# Altium schematic sheet Isolated Power Supplies Rev 1b.SchDoc("Isolated Power Supplies Rev 1b")
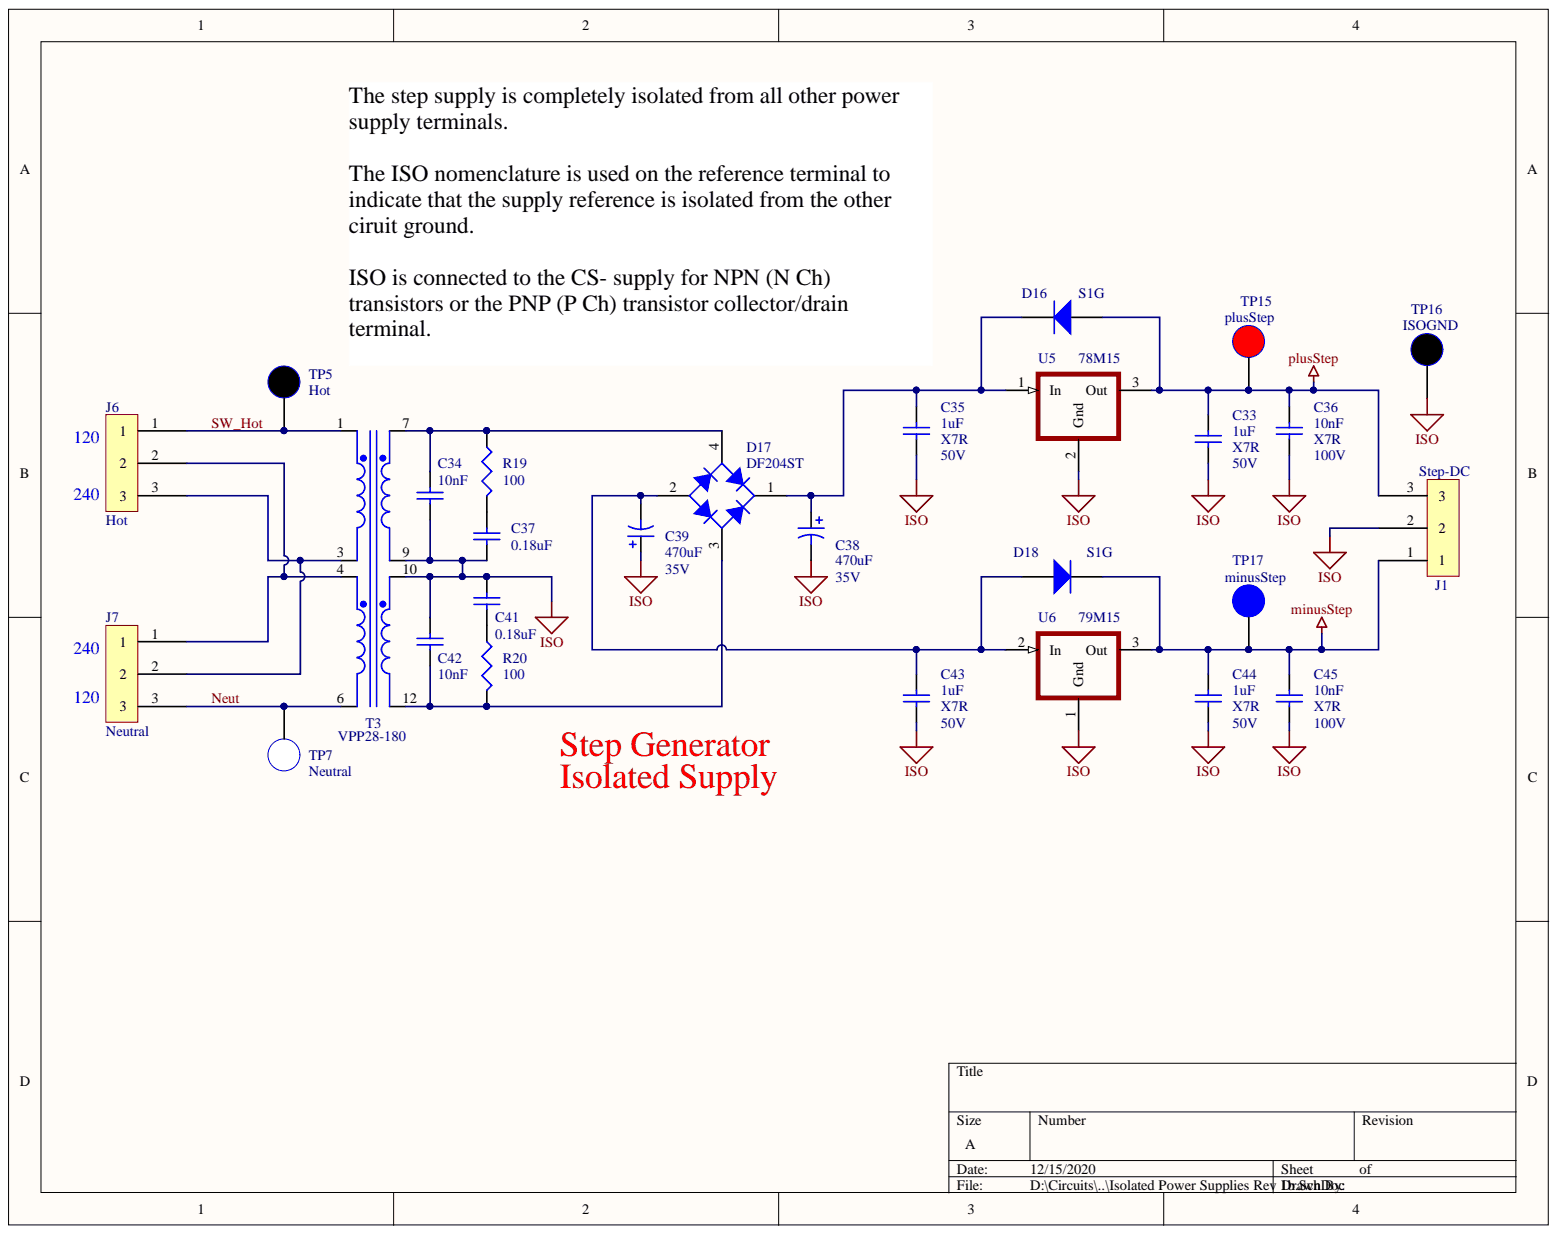
[diagram p0: Isolated Power Supplies Rev 1b.SchDoc]

## Parts
C33  1uF  Voltage Rating (DC): 50V  Dielectric: X7R  [CAPC3216M]
C34  10nF  [CAPC3216M]
C35  1uF  Voltage Rating (DC): 50V  Dielectric: X7R  [CAPC3216M]
C36  10nF  Voltage Rating (DC): 100V  Dielectric: X7R  [CAPC3216M]
C37  0.18uF  [CAPC4532M]
C38  470uF  Voltage Rating: 35V  [CAPPR5-10x12.5-STEP]
C39  470uF  [CAPPR5-10x12.5-STEP]
C41  0.18uF  [CAPC4532M]
C42  10nF  [CAPC3216M]
C43  1uF  Voltage Rating (DC): 50V  Dielectric: X7R  [CAPC3216M]
C44  1uF  Voltage Rating (DC): 50V  Dielectric: X7R  [CAPC3216M]
C45  10nF  Voltage Rating (DC): 100V  Dielectric: X7R  [CAPC3216M]
D16  S1G  [SMA-D]
D17  value DF204ST  [DFS]  (hidden comment: Bridge)
D18  S1G  [SMA-D]
J1  Step-DC  [DTA-100-3]
J6  Hot  [TE-EP-3]
J7  Neutral  [TE-EP-3]
R19  100  [RESC6432BM]
R20  100  [RESC6432BM]
T3  value VPP28-180  [VPPXX-5W]  (hidden comment: TRIAD_VPP)
TP5  Hot  [TP-.063]
TP7  Neutral  [TP-.063]
TP15  plusStep  [TP-.038]
TP16  ISOGND  [TP-.038]
TP17  minusStep  [TP-.038]
U5  78M15  [DPAK-M]
U6  79M15  [DPAK-M]

## Nets
ISO: C33.2 C34.2 C35.2 C36.1 C37.2 C38.2 C39.1 C41.1 C42.1 C43.2 C44.2 C45.1 J1.2 T3.9 T3.10 TP16.1 U5.2 U6.1
minusStep: C44.1 C45.2 D18.2 J1.1 TP17.1 U6.3
plusStep: C33.1 C36.2 D16.1 J1.3 TP15.1 U5.3
Neut: J7.3 T3.6 TP7.1
SW_Hot: J6.1 T3.1 TP5.1
NetC34_1: C34.1 D17.4 R19.1 T3.7
NetC35_1: C35.1 C38.1 D16.2 D17.1 U5.1
NetC37_1: C37.1 R19.2
NetC39_2: C39.2 C43.1 D17.2 D18.1 U6.2
NetC41_2: C41.2 R20.1
NetC42_2: C42.2 D17.3 R20.2 T3.12
NetJ6_2: J6.2 J7.1 T3.4
NetJ6_3: J6.3 J7.2 T3.3

## Library data of the parts
C33 C35 C43 C44 = Cap: CAP CER 1UF 50V X7R 1206; Manufacturer Part Number: C1206C105K5RACTU; Manufacturer: KEMET; Tolerance: 10%; Dielectric Material: Ceramic; Width: 0.063inch; Voltage Rating: 50V; Voltage: 50V; Value: 1uF; Thickness: 0.043inch; Termination: SMD/SMT; Supplier Part Number: 399-8147-6-ND; Supplier: Digi-Key; Simulation: CAP; Signal Integrity: Cap; Series: C; REACH SVHC: No SVHC; Radiation Hardening: No; Packaging: Tape and Reel; Package Quantity: 1; Number of Pins: 2; Mount: Surface Mount; Min Operating Temperature: -55°C; Max Operating Temperature: 125°C; Library Name: Miscellaneous Devices.IntLib; Length: 3.2mm; Height: 1mm; Depth: 1.6mm; Construction: Flat; Component Kind: Standard; Case Code (Metric): 3216; Case Code (Imperial): 1206; Case/Package: 1206; Capacitance: 1uF
C34 C42 = Cap: CAP PEN 10NF 100V 5% 1206; Manufacturer Part Number: CB017E0103JBA; Manufacturer: Kyocera AVX; Voltage Rating (AC): 63V; Voltage Rating (DC): 100V; Voltage Rating: 100V; Value: 10nF; Tolerance: 5%; Termination: SMD/SMT; Supplier Part Number: 478-13090-1-ND; Supplier: Digi-Key; Simulation: CAP; Signal Integrity: Cap; REACH SVHC: No SVHC; Radiation Hardening: No; Packaging: Tape and Reel; Package Quantity: 3900; Mount: Surface Mount; Min Operating Temperature: -55°C; Max Operating Temperature: 125°C; Library Name: Miscellaneous Devices.IntLib; Length: 3.3mm; Depth: 1.6mm; Contact Plating: Tin,Matte; Component Kind: Standard; Case Code (Metric): 3216; Case Code (Imperial): 1206; Case/Package: 1206; Capacitance: 10nF
C36 C45 = Cap: CAP CER 10NF 100V X7R 1206; Manufacturer Part Number: C1206C103K1RACTU; Manufacturer: KEMET; Tolerance: 10%; Dissipation Factor: 2.5%; Dielectric Material: Ceramic; Width: 0.063inch; Voltage Rating: 100V; Voltage: 100V; Value: 10nF; Thickness: 0.035inch; Termination: SMD/SMT; Supplier Part Number: 399-1236-6-ND; Supplier: Digi-Key; Simulation: CAP; Signal Integrity: Cap; Series: C; REACH SVHC: No SVHC; Radiation Hardening: No; Packaging: Tape and Reel; Package Quantity: 1; Number of Pins: 2; Mount: Surface Mount,PCB; Min Operating Temperature: -55°C; Max Operating Temperature: 125°C; Library Name: Miscellaneous Devices.IntLib; Length: 3.2mm; Height: 0.78mm; Depth: 1.6mm; Contact Plating: Tin,Matte; Construction: Flat; Component Kind: Standard; Case Code (Metric): 3216; Case Code (Imperial): 1206; Case/Package: 1206; Capacitance: 10nF
C37 C41 = Cap: CAP FILM 0.18UF 5% 50VDC 1812; Manufacturer Part Number: LDECC3180JA5N00; Manufacturer: KEMET; Value: 0.18uF; Supplier Part Number: 399-18519-1-ND; Supplier: Digi-Key; Simulation: CAP; Signal Integrity: Cap; Library Name: Miscellaneous Devices.IntLib; Component Kind: Standard; Capacitance: 0.18uF
C38 C39 = Cap Pol3: CAP ALUM 470UF 20% 35V RADIAL; Manufacturer Part Number: 35ZL470MEFC10X20; Manufacturer: Rubycon; Ripple Current: 1.82A; Life (Hours): 4000Hour; Leakage Current: 3uA; Lead Diameter: 0.6mm; Impedance: 23mR; Dielectric Material: Aluminium; Voltage: 35V; Value: 470uF; Tolerance: 20%; Termination: Radial; Supplier Part Number: 35ZL470MEFC10X20-ND; Supplier: Digi-Key; Simulation: CAP; Signal Integrity: Cap Pol; REACH SVHC: Unknown; Radiation Hardening: No; Packaging: Bulk; Package Quantity: 1000; Mount: Through Hole; Min Operating Temperature: -40°C; Max Operating Temperature: 105°C; Library Name: Miscellaneous Devices.IntLib; Lead Pitch: 5mm; Lead Free: Lead Free; Height - Seated (Max): 0.866inch; Height: 20mm; Diameter: 10mm; Component Kind: Standard; Case/Package: Radial; Capacitance: 470uF
  C39: Voltage Rating: 35V
D16 D18 = Diode 1N4002: Diode Switching 400V 1A 2-Pin DO-214AC T/R; Manufacturer: ON Semiconductor / Fairchild; Reverse Recovery Time: 1.8us; Recovery Time: 1800ns; Power Dissipation: 1.4W; Polarity: Standard; Peak Reverse Current: 1uA; Peak Non-Repetitive Surge Current: 30A; Max Surge Current: 40A; Forward Voltage: 1.1V; Element Configuration: Single; Capacitance: 12pF; Width: 2.95mm; Weight: 0.106g; Voltage Rating (DC): 400V; Value: S1G; Supplier Part Number: S1GFSCT-ND; Supplier: Digi-Key; Simulation: 1N4002; Signal Integrity: 1N4002; Reverse Voltage: 400V; REACH SVHC: No SVHC; Radiation Hardening: No; Packaging: Tape and Reel; Package Quantity: 1; Output Current: 1A; Number of Pins: 2; Mount: Surface Mount; Min Operating Temperature: -55°C; Max Reverse Voltage (DC): 400V; Max Repetitive Reverse Voltage (Vrrm): 400V; Max Operating Temperature: 150°C; Max Forward Surge Current (Ifsm): 30A; Manufacturer Part Number: S1G; Library Name: Miscellaneous Devices.IntLib; Length: 4.75mm; Lead Free: Lead Free; Height: 2.2mm; Forward Current: 1A; Current Rating: 1A; Contact Plating: Tin,Matte; ComponentLink2URL: http://www.fairchildsemi.com/ds/1N%2F1N4001.pdf; ComponentLink2Description: Datasheet; ComponentLink1URL: http://www.fairchildsemi.com/; ComponentLink1Description: Manufacturer Link; Component Kind: Standard; Case/Package: SMA; Average Rectified Current: 1A
D17 = Bridge1: RECT BRIDGE GPP 400V 2A DFS; Manufacturer Part Number: DF204ST-G; Manufacturer: Comchip; Max Surge Current: 60A; Max Reverse Leakage Current: 10uA; Width: 10.41mm; Supplier Part Number: 641-1464-1-ND; Supplier: Digi-Key; Simulation: BRIDGE; Packaging: Tape and Reel; Package Quantity: 1; Number of Pins: 4; Mount: Surface Mount; Min Operating Temperature: -55°C; Max Operating Temperature: 150°C; Library Name: Miscellaneous Devices.IntLib; Length: 8.64mm; Height: 3.81mm; Component Kind: Standard; Case/Package: SMD/SMT
J1 = 3-Pin: CONN HEADER VERT 3POS 2.54MM; Manufacturer: TE Connectivity; Voltage Rating (AC): 250V; Value: 640456-3; Tail Length: 0.14inch; Strain Relief: No (Without); Plating Thickness: 3.81um; PCB Thickness: 0.063inch; PCB Mount Retention: Without; PCB Mounting Orientation: Vertical; Number of Positions: 3; Mating Connector Lock: Yes (With); Gender: Male; Current Rating: 5A; Contact Style: Straight; Contact Resistance: 6mR; Contact Post Length: 3.56mm; Connector Type: Connector,Pin,Plug,Header; Connector System: Wire-to-Board; Circuit Application: Signal; Approvals: CSA; Voltage Rating: 250V; Termination: Solder; Supplier Part Number: A19470-ND; Supplier: Digi-Key; Shrouded: No (Without); Sealable: No (Without); REACH SVHC: Unknown; Radiation Hardening: No; Pitch: 2.54mm; PCB Mount Alignment: No (Without); Panel Mount Retention: No (Without); Packaging: Bulk; Package Quantity: 5000; Orientation: Straight; Number of Rows: 1; Number of Contacts: 3; Mounting Ears: No (Without); Mount: Through Hole; Min Operating Temperature: -67°C; Max Voltage Rating (AC): 250V; Max Operating Temperature: 221°C; Mating Retention: No (Without); Mating Alignment: No (Without); Manufacturer Part Number: 640456-3; Library Name: MY_CONNECTORS.SchLib; Length: 0.4inch; Lead Free: Lead Free; Insulation Material: Thermoplastic; Insulation Color: Natural; Housing Material: Polyester; Housing Color: Natural; Height: 7.87mm; Flammability Rating: UL94 V-0; Fastening Type: Friction; ELV: Compliant; Depth: 5.72mm; Contact Shape: Square; Contact Retention: No (Without); Contact Plating: Tin; Contact Mating Length: 7.49mm; Contact Material: Copper Alloy; Contact Gender: Male; Contact Current Rating: 5A; Component Kind: Standard; Color: Beige,White; Backwall/Post Interruptions: No (Without)
J6 J7 = 3-Pin: CONN HEADER VERT 3POS 2.54MM; Manufacturer: TE Connectivity; Value: 1744037-3; Strain Relief: No (Without); Plating Thickness: 120um; Pitch: 5.08mm; PCB Thickness: 0.063mm; PCB Mount Retention: Without; PCB Mounting Orientation: Vertical; Number of Power Positions: 3; Max Voltage Rating (AC): 250V; Mating Retention: Yes (With); Contact Current Rating: 8A; Connector Type: Tab; Connector System: Wire-to-Board; Circuit Application: Power; Width: 0.323inch; Termination: Solder; Supplier Part Number: 1744037-3-ND; Supplier: Digi-Key; Series: Economy Power; Selectively Loaded: No (Without); Sealable: No (Without); PCB Mount Alignment: No (Without); Packaging: Bag; Package Quantity: 1000; Orientation: Straight; Number of Rows: 1; Number of Positions: 3; Number of Contacts: 3; Mount: Through Hole; Min Operating Temperature: -25°C; Max Operating Temperature: 105°C; Mating Alignment: Yes (With); Material: Nylon; Manufacturer Part Number: 1744037-3; Library Name: MY_CONNECTORS.SchLib; Length: 12.76mm; Lead Free: Not Applicable; Housing Material: Nylon; Housing Color: Natural; Height: 0.4291inch; Flammability Rating: UL94 V-0; ELV: Compliant; Depth: 8.52mm; Contact Type: Male Pin; Contact Retention: No (Without); Contact Plating: Tin; Contact Mating Length: 0.303inch; Contact Material: Copper Alloy; Component Kind: Standard; Color: Natural; Backwall/Post Interruptions: No (Without)
R19 R20 = Res3: RES 100 OHM 1% 1W 2512; Manufacturer Part Number: RMCF2512FT100R; Manufacturer: Stackpole Electronics; Temperature Coefficient: 100ppm/°C; Resistance: 100R; Width: 0.126inch; Value: 100; Tolerance: 1%; Supplier Part Number: RMCF2512FT100RCT-ND; Supplier: Digi-Key; Simulation: RESISTOR; Signal Integrity: Res; Radiation Hardening: No; Power Rating: 1W; Packaging: Tape and Reel; Number of Terminations: 2; Mount: Surface Mount; Min Operating Temperature: -55°C; Max Power Dissipation: 1W; Max Operating Temperature: 155°C; Library Name: Miscellaneous Devices.IntLib; Length: 0.248inch; Lead Free: Lead Free; Height: 0.028inch; Features: Automotive AEC-Q200; Contact Plating: Tin,Matte; Composition: Thick Film; Component Kind: Standard; Case Code (Metric): 6432; Case Code (Imperial): 2512; Case/Package: 2512
T3 = TRIAD_VPP: XFRMR LAMINATED 5VA THRU HOLE; Manufacturer: Triad Magnetics; Secondary Winding(s): Dual; Power Rating: 5W; Output Voltage: 28V; Output Current: 360mA; Max Output Current: 180mA; Width: 33.32mm; Weight: 8.400001oz; Termination: PC Pin; Supplier Part Number: 237-1086-ND; Supplier: Digi-Key; Primary Winding(s): Dual; Packaging: Bulk; Package Quantity: 20; Number of Pins: 8; Mount: Through Hole; Max Power Dissipation: 5W; Manufacturer Part Number: VPP28-180; Library Name: My_Trans.SchLib; Length: 41.28mm; Lead Free: Lead Free; Height - Seated (Max): 34.93mm; Height: 34.9mm; Element Configuration: Dual; Current Rating: 360mA; Component Kind: Standard
TP5 TP16 = TP-Round-Black: TP Thru-Hole; Manufacturer Part Number: Gold; Manufacturer: UW; Value: TP Thru-Hole; Library Name: MY_TP.SchLib; Component Kind: Standard
  TP5: Supplier Part Number: Gold
  TP16: Supplier Part Num,ber: Gold; Supplier: UW
TP7 = TP-Round-White: TP Thru-Hole; Manufacturer Part Number: Gold; Manufacturer: UW; Value: TP Thru-Hole; Supplier Part Number: Gold; Supplier: UW; Library Name: MY_TP.SchLib; Component Kind: Standard
TP15 = TP-Round-Red: TP Thru-Hole; Manufacturer Part Number: Gold; Manufacturer: UW; Value: TP Thru-Hole; Supplier Part Num,ber: Gold; Supplier: UW; Library Name: MY_TP.SchLib; Component Kind: Standard
TP17 = TP-Round-Blue: TP Thru-Hole; Manufacturer Part Number: Gold; Manufacturer: UW; Value: TP Thru-Hole; Supplier Part Num,ber: Gold; Supplier: UW; Library Name: MY_TP.SchLib; Component Kind: Standard
U5 = 78M00-3PIN: IC REG LINEAR 15V 500MA DPAK; Manufacturer Part Number: MC78M15CDTRKG; Manufacturer: ON Semiconductor; Power Supply Rejection Ratio (PSRR): 70dB; Polarity: Positive; Output Type: Fixed; Number of Regulators: 1; Min Input Voltage: 17V; Max Input Voltage: 35V; Dropout Voltage: 2V; Width: 6.22mm; Value: 78M15; Supplier Part Number: MC78M15CDTRKGOSDKR-ND; Supplier: Digi-Key; REACH SVHC: No SVHC; Radiation Hardening: No; Packaging: Tape and Reel; Package Quantity: 1; Output Voltage: 15V; Output Current: 500mA; Number of Pins: 3; Number of Outputs: 1; Nominal Output Voltage: 15V; Mount: Surface Mount; Min Output Voltage: 15V; Min Operating Temperature: 0°C; Max Output Current: 500mA; Max Operating Temperature: 125°C; Length: 6.73mm; Lead Free: Lead Free; Height: 2.38mm; Halogen Free: Halogen Free; Current Rating: 500mA; Contact Plating: Tin,Matte; Component Kind: Standard; Case/Package: DPAK; Library Name: MY_Vregs.SchLib
U6 = 79M00-3PIN: IC REG LINEAR -15V 500MA DPAK; Manufacturer Part Number: MC79M15CDTRKG; Manufacturer: ON Semiconductor; Power Supply Rejection Ratio (PSRR): 60dB; Polarity: Negative; Output Voltage Accuracy: 4%; Output Type: Fixed; Number of Regulators: 1; Min Input Voltage: -17V; Max Output Voltage: -15.6V; Max Input Voltage: -35V; Dropout Voltage: 1.1V; Value: 79M15; Supplier Part Number: MC79M15CDTRKGOSDKR-ND; Supplier: Digi-Key; REACH SVHC: No SVHC; Radiation Hardening: No; Packaging: Tape and Reel; Package Quantity: 1; Output Voltage: -15V; Output Current: 500mA; Number of Pins: 3; Number of Outputs: 1; Nominal Output Voltage: -15V; Mount: Surface Mount; Min Output Voltage: -15V; Min Operating Temperature: 0°C; Max Output Current: 500mA; Max Operating Temperature: 125°C; Lead Free: Lead Free; Halogen Free: Halogen Free; Contact Plating: Tin,Matte; Component Kind: Standard; Case/Package: DPAK; Accuracy: 4%; Library Name: MY_Vregs.SchLib
